# Altium schematic sheet 08 - BANK 35 PMOD Interfaces.SchDoc
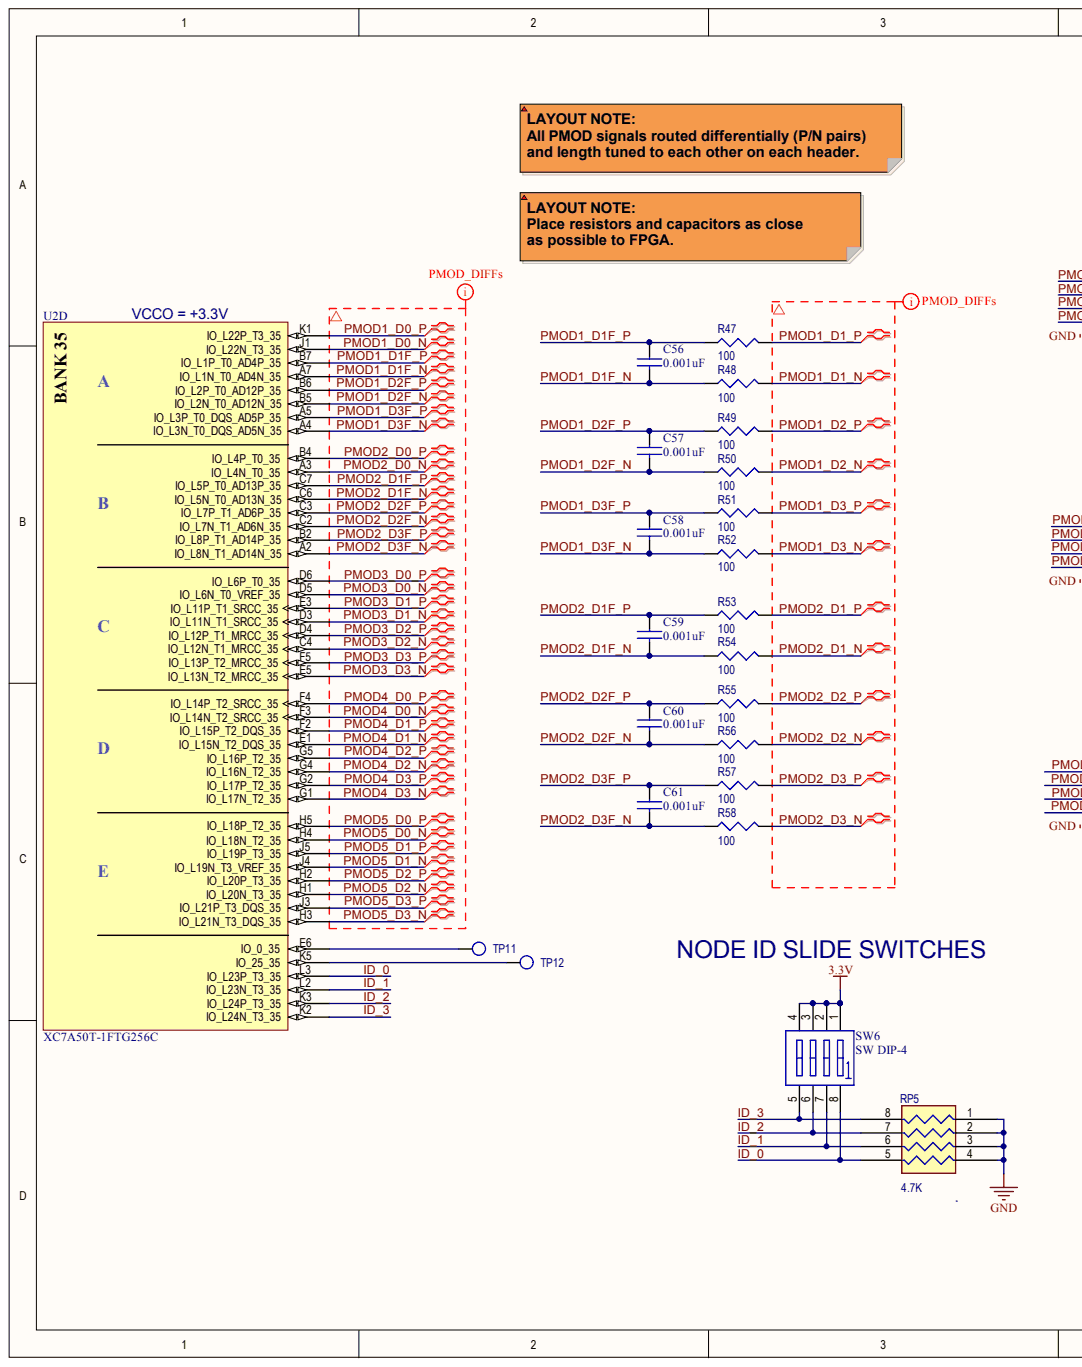
[diagram p0: 08 - BANK 35 PMOD Interfaces.SchDoc, left]
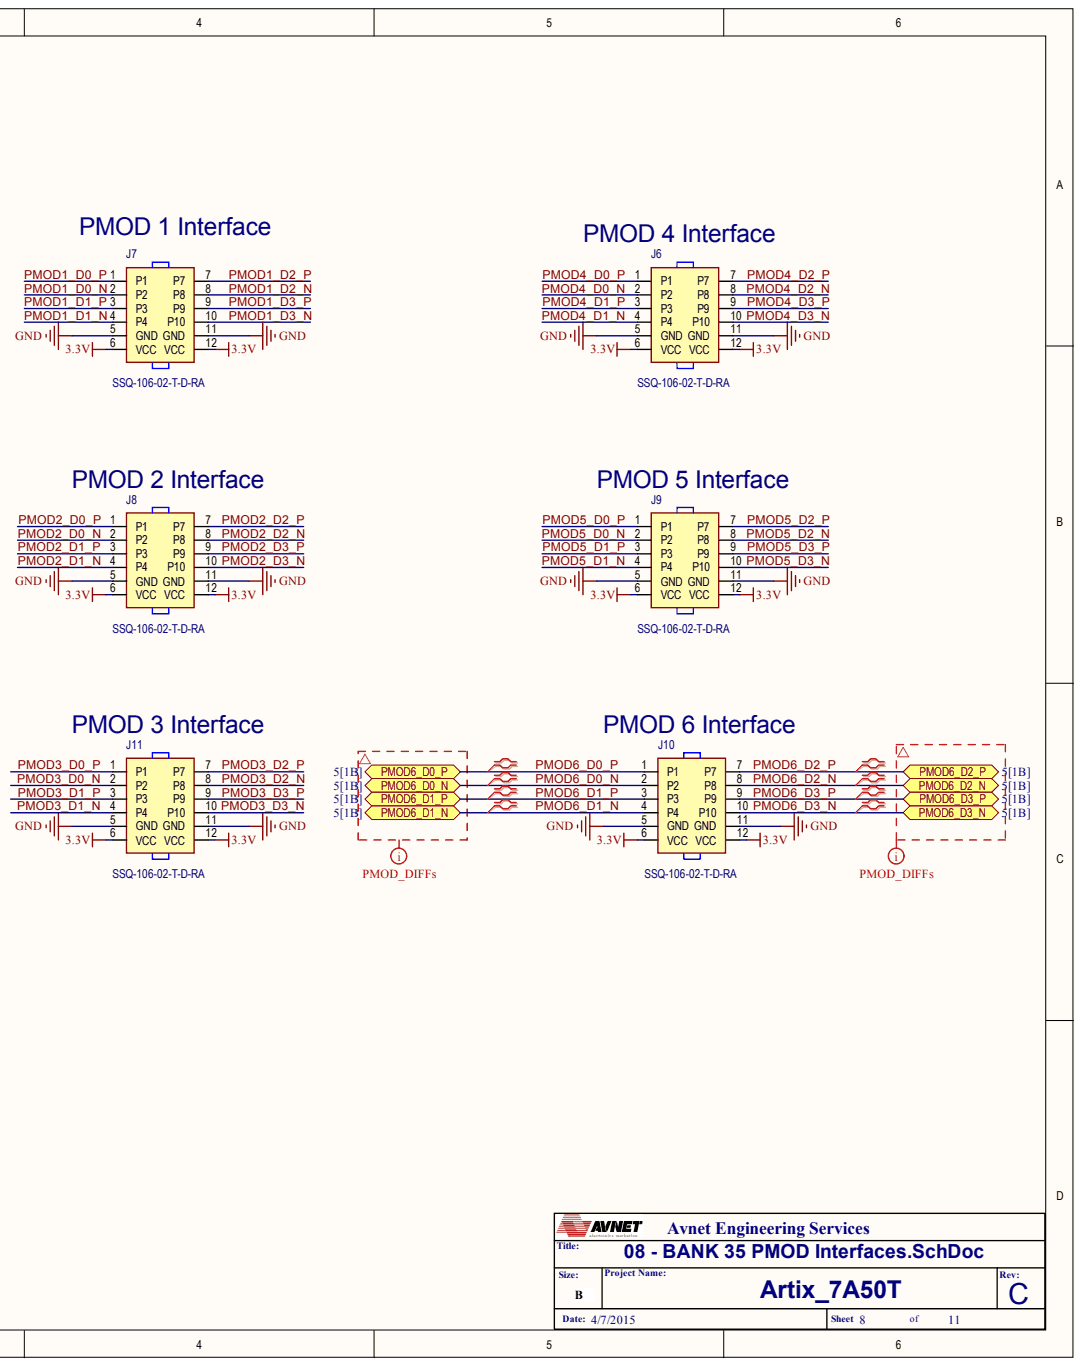
[diagram p1: 08 - BANK 35 PMOD Interfaces.SchDoc, right]

## Parts
C56  value 0.001uF  [C0201]  (hidden comment: 0.001uF 6.3V 0201)
C57  value 0.001uF  [C0201]  (hidden comment: 0.001uF 6.3V 0201)
C58  value 0.001uF  [C0201]  (hidden comment: 0.001uF 6.3V 0201)
C59  value 0.001uF  [C0201]  (hidden comment: 0.001uF 6.3V 0201)
C60  value 0.001uF  [C0201]  (hidden comment: 0.001uF 6.3V 0201)
C61  value 0.001uF  [C0201]  (hidden comment: 0.001uF 6.3V 0201)
J6  MFGPN: SSQ-106-02-T-D-RA  [CON_SAM_SSQ-106-02-T-D-RA-PMOD]  (hidden comment: BCS-106-L-D-TE)
J7  MFGPN: SSQ-106-02-T-D-RA  [CON_SAM_SSQ-106-02-T-D-RA-PMOD]  (hidden comment: BCS-106-L-D-TE)
J8  MFGPN: SSQ-106-02-T-D-RA  [CON_SAM_SSQ-106-02-T-D-RA-PMOD]  (hidden comment: BCS-106-L-D-TE)
J9  MFGPN: SSQ-106-02-T-D-RA  [CON_SAM_SSQ-106-02-T-D-RA-PMOD]  (hidden comment: BCS-106-L-D-TE)
J10  MFGPN: SSQ-106-02-T-D-RA  [CON_SAM_SSQ-106-02-T-D-RA-PMOD]  (hidden comment: BCS-106-L-D-TE)
J11  MFGPN: SSQ-106-02-T-D-RA  [CON_SAM_SSQ-106-02-T-D-RA-PMOD]  (hidden comment: BCS-106-L-D-TE)
R47  value 100  [R0402]  (hidden comment: 0402)
R48  value 100  [R0402]  (hidden comment: 0402)
R49  value 100  [R0402]  (hidden comment: 0402)
R50  value 100  [R0402]  (hidden comment: 0402)
R51  value 100  [R0402]  (hidden comment: 0402)
R52  value 100  [R0402]  (hidden comment: 0402)
R53  value 100  [R0402]  (hidden comment: 0402)
R54  value 100  [R0402]  (hidden comment: 0402)
R55  value 100  [R0402]  (hidden comment: 0402)
R56  value 100  [R0402]  (hidden comment: 0402)
R57  value 100  [R0402]  (hidden comment: 0402)
R58  value 100  [R0402]  (hidden comment: 0402)
RP5  value 4.7K  [RPACK4-LCC]  (hidden comment: CAT16-472J4LF)
SW6  SW DIP-4  [DIPSW4]
TP11  TPS  [TP24TH]  (value not drawn)
TP12  TPS  [TP24TH]  (value not drawn)
U2  MFGPN: XC7A50T-1FTG256C  [FTG256]  (hidden comment: XNLX-XC7A50T-XNLX-FTG256)  (part D)

## Ports
PMOD6_D0_N, PMOD6_D0_P, PMOD6_D1_N, PMOD6_D1_P, PMOD6_D2_N, PMOD6_D2_P, PMOD6_D3_N, PMOD6_D3_P

## Nets
3.3V: J6.6(VCC) J6.12(VCC) J7.6(VCC) J7.12(VCC) J8.6(VCC) J8.12(VCC) J9.6(VCC) J9.12(VCC) J10.6(VCC) J10.12(VCC) J11.6(VCC) J11.12(VCC) SW6.1 SW6.2 SW6.3 SW6.4
GND: J6.5(GND) J6.11(GND) J7.5(GND) J7.11(GND) J8.5(GND) J8.11(GND) J9.5(GND) J9.11(GND) J10.5(GND) J10.11(GND) J11.5(GND) J11.11(GND) RP5.1 RP5.2 RP5.3 RP5.4
ID_0: RP5.5 SW6.8 U2.L3(IO_L23P_T3_35)
ID_1: RP5.6 SW6.7 U2.L2(IO_L23N_T3_35)
ID_2: RP5.7 SW6.6 U2.K3(IO_L24P_T3_35)
ID_3: RP5.8 SW6.5 U2.K2(IO_L24N_T3_35)
PMOD1_D0_N: J7.2(P2) U2.J1(IO_L22N_T3_35)
PMOD1_D0_P: J7.1(P1) U2.K1(IO_L22P_T3_35)
PMOD1_D1_N: J7.4(P4) R48.2
PMOD1_D1_P: J7.3(P3) R47.2
PMOD1_D1F_N: C56.2 R48.1 U2.A7(IO_L1N_T0_AD4N_35)
PMOD1_D1F_P: C56.1 R47.1 U2.B7(IO_L1P_T0_AD4P_35)
PMOD1_D2_N: J7.8(P8) R50.2
PMOD1_D2_P: J7.7(P7) R49.2
PMOD1_D2F_N: C57.2 R50.1 U2.B5(IO_L2N_T0_AD12N_35)
PMOD1_D2F_P: C57.1 R49.1 U2.B6(IO_L2P_T0_AD12P_35)
PMOD1_D3_N: J7.10(P10) R52.2
PMOD1_D3_P: J7.9(P9) R51.2
PMOD1_D3F_N: C58.2 R52.1 U2.A4(IO_L3N_T0_DQS_AD5N_35)
PMOD1_D3F_P: C58.1 R51.1 U2.A5(IO_L3P_T0_DQS_AD5P_35)
PMOD2_D0_N: J8.2(P2) U2.A3(IO_L4N_T0_35)
PMOD2_D0_P: J8.1(P1) U2.B4(IO_L4P_T0_35)
PMOD2_D1_N: J8.4(P4) R54.2
PMOD2_D1_P: J8.3(P3) R53.2
PMOD2_D1F_N: C59.2 R54.1 U2.C6(IO_L5N_T0_AD13N_35)
PMOD2_D1F_P: C59.1 R53.1 U2.C7(IO_L5P_T0_AD13P_35)
PMOD2_D2_N: J8.8(P8) R56.2
PMOD2_D2_P: J8.7(P7) R55.2
PMOD2_D2F_N: C60.2 R56.1 U2.C2(IO_L7N_T1_AD6N_35)
PMOD2_D2F_P: C60.1 R55.1 U2.C3(IO_L7P_T1_AD6P_35)
PMOD2_D3_N: J8.10(P10) R58.2
PMOD2_D3_P: J8.9(P9) R57.2
PMOD2_D3F_N: C61.2 R58.1 U2.A2(IO_L8N_T1_AD14N_35)
PMOD2_D3F_P: C61.1 R57.1 U2.B2(IO_L8P_T1_AD14P_35)
PMOD3_D0_N: J11.2(P2) U2.D5(IO_L6N_T0_VREF_35)
PMOD3_D0_P: J11.1(P1) U2.D6(IO_L6P_T0_35)
PMOD3_D1_N: J11.4(P4) U2.D3(IO_L11N_T1_SRCC_35)
PMOD3_D1_P: J11.3(P3) U2.E3(IO_L11P_T1_SRCC_35)
PMOD3_D2_N: J11.8(P8) U2.C4(IO_L12N_T1_MRCC_35)
PMOD3_D2_P: J11.7(P7) U2.D4(IO_L12P_T1_MRCC_35)
PMOD3_D3_N: J11.10(P10) U2.E5(IO_L13N_T2_MRCC_35)
PMOD3_D3_P: J11.9(P9) U2.F5(IO_L13P_T2_MRCC_35)
PMOD4_D0_N: J6.2(P2) U2.F3(IO_L14N_T2_SRCC_35)
PMOD4_D0_P: J6.1(P1) U2.F4(IO_L14P_T2_SRCC_35)
PMOD4_D1_N: J6.4(P4) U2.E1(IO_L15N_T2_DQS_35)
PMOD4_D1_P: J6.3(P3) U2.F2(IO_L15P_T2_DQS_35)
PMOD4_D2_N: J6.8(P8) U2.G4(IO_L16N_T2_35)
PMOD4_D2_P: J6.7(P7) U2.G5(IO_L16P_T2_35)
PMOD4_D3_N: J6.10(P10) U2.G1(IO_L17N_T2_35)
PMOD4_D3_P: J6.9(P9) U2.G2(IO_L17P_T2_35)
PMOD5_D0_N: J9.2(P2) U2.H4(IO_L18N_T2_35)
PMOD5_D0_P: J9.1(P1) U2.H5(IO_L18P_T2_35)
PMOD5_D1_N: J9.4(P4) U2.J4(IO_L19N_T3_VREF_35)
PMOD5_D1_P: J9.3(P3) U2.J5(IO_L19P_T3_35)
PMOD5_D2_N: J9.8(P8) U2.H1(IO_L20N_T3_35)
PMOD5_D2_P: J9.7(P7) U2.H2(IO_L20P_T3_35)
PMOD5_D3_N: J9.10(P10) U2.H3(IO_L21N_T3_DQS_35)
PMOD5_D3_P: J9.9(P9) U2.J3(IO_L21P_T3_DQS_35)
PMOD6_D0_N: J10.2(P2) ; port PMOD6_D0_N
PMOD6_D0_P: J10.1(P1) ; port PMOD6_D0_P
PMOD6_D1_N: J10.4(P4) ; port PMOD6_D1_N
PMOD6_D1_P: J10.3(P3) ; port PMOD6_D1_P
PMOD6_D2_N: J10.8(P8) ; port PMOD6_D2_N
PMOD6_D2_P: J10.7(P7) ; port PMOD6_D2_P
PMOD6_D3_N: J10.10(P10) ; port PMOD6_D3_N
PMOD6_D3_P: J10.9(P9) ; port PMOD6_D3_P
NetTP11_1: TP11.1 U2.E6(IO_0_35)
NetTP12_1: TP12.1 U2.K5(IO_25_35)
N00373:
N00374:
N00375:
N00376:

## Library data of the parts
C56-C61 = CAPC 0.001uF 6.3V 0201: Ceramic Chip Capacitor 0201 0.001uF 6.3v 10% X7R; AES Part #: AVPC-0000091; Preferred Part #: PIC ECJ-ZEB0J102K; MFGPN: GRM033R71E102KA01D; Component Kind: Standard; Library Name: Passive.SchLib; Signal Integrity: CAP; Simulation: CAP; Status: Preliminary
J6-J11 = (no symbol name): Header TH 100mil 6X2 Right Angle PMOD Horizontal; PartCode: EH; AVLNo: xxx; Device Type: Header - Horizontal; MFG: SAM; MFG Code: SAT; Col-Row: 6X2; Component Kind: Standard; ComponentLink1Description: Datasheet; Library Name: Zynq_Z010_ZedStick.SchLib; Pitch: 100mil; PkgType: TH; Status: Preliminary
R47-R58 = AVPR-0000030: 100 ohm 1% 0402; Database Table Name: Passive-Res$; MFG Code: DAL; MFGPN: CRCW0402100RFKED; Component Kind: Standard; Library Name: Avnet_DBlib.DbLib
RP5 = RESCAXE 4.7K: Res Thick Film Array 4.7K Ohm 5% ISOL Molded 8-Pin 1206 Concave SMD Paper T/R; MFG Code: BNS; Component Kind: Standard; MFGPN: CAT16-472J4LF; Note: Res Thick Film Array 4.7K Ohm 5% ISOL Molded 8-Pin 1206 Concave SMD Paper T/R; Library Name: ZYNQ_Z7045_MITX_REVA.SCHLIB
SW6 = SW DIP-4: DIP Switch, 4 Position, SPST; MFG: TE; MFGPN: GDH04STR04; Code_IPC: SOIC127P600-8; Code_JEDEC: MS-012-AA; Component Kind: Standard; LatestRevisionDate: 29-May-2009; LatestRevisionNote: IPC-7351 Footprint Added.; Library Name: Miscellaneous Devices.IntLib; PackageDescription: 8-Pin Small Outline Integrated Circuit 1.27 mm Pitch; PackageReference: SO8; PackageVersion: Dec-2002; Published: 24-Mar-1999; Simulation: dpsw4; Publisher: Altium Limited
TP11 TP12 = AVPO-0000039: Test Point 24 mil pad, round, 10 mil hole, small symbol TPS TP24TH; MFG Code: None; Component Kind: Standard; DNP: DNP; MFGPN: None; Library Name: ZYNQ_Z7045_MITX_REVA.SCHLIB
U2 = XNLX-XC7A50T-XNLX-FTG256: PKG: FBGA256; Component Kind: Standard; Library Name: Artix_7A50_1.SCHLIB
